# Altium schematic sheet Sensor.SchDoc
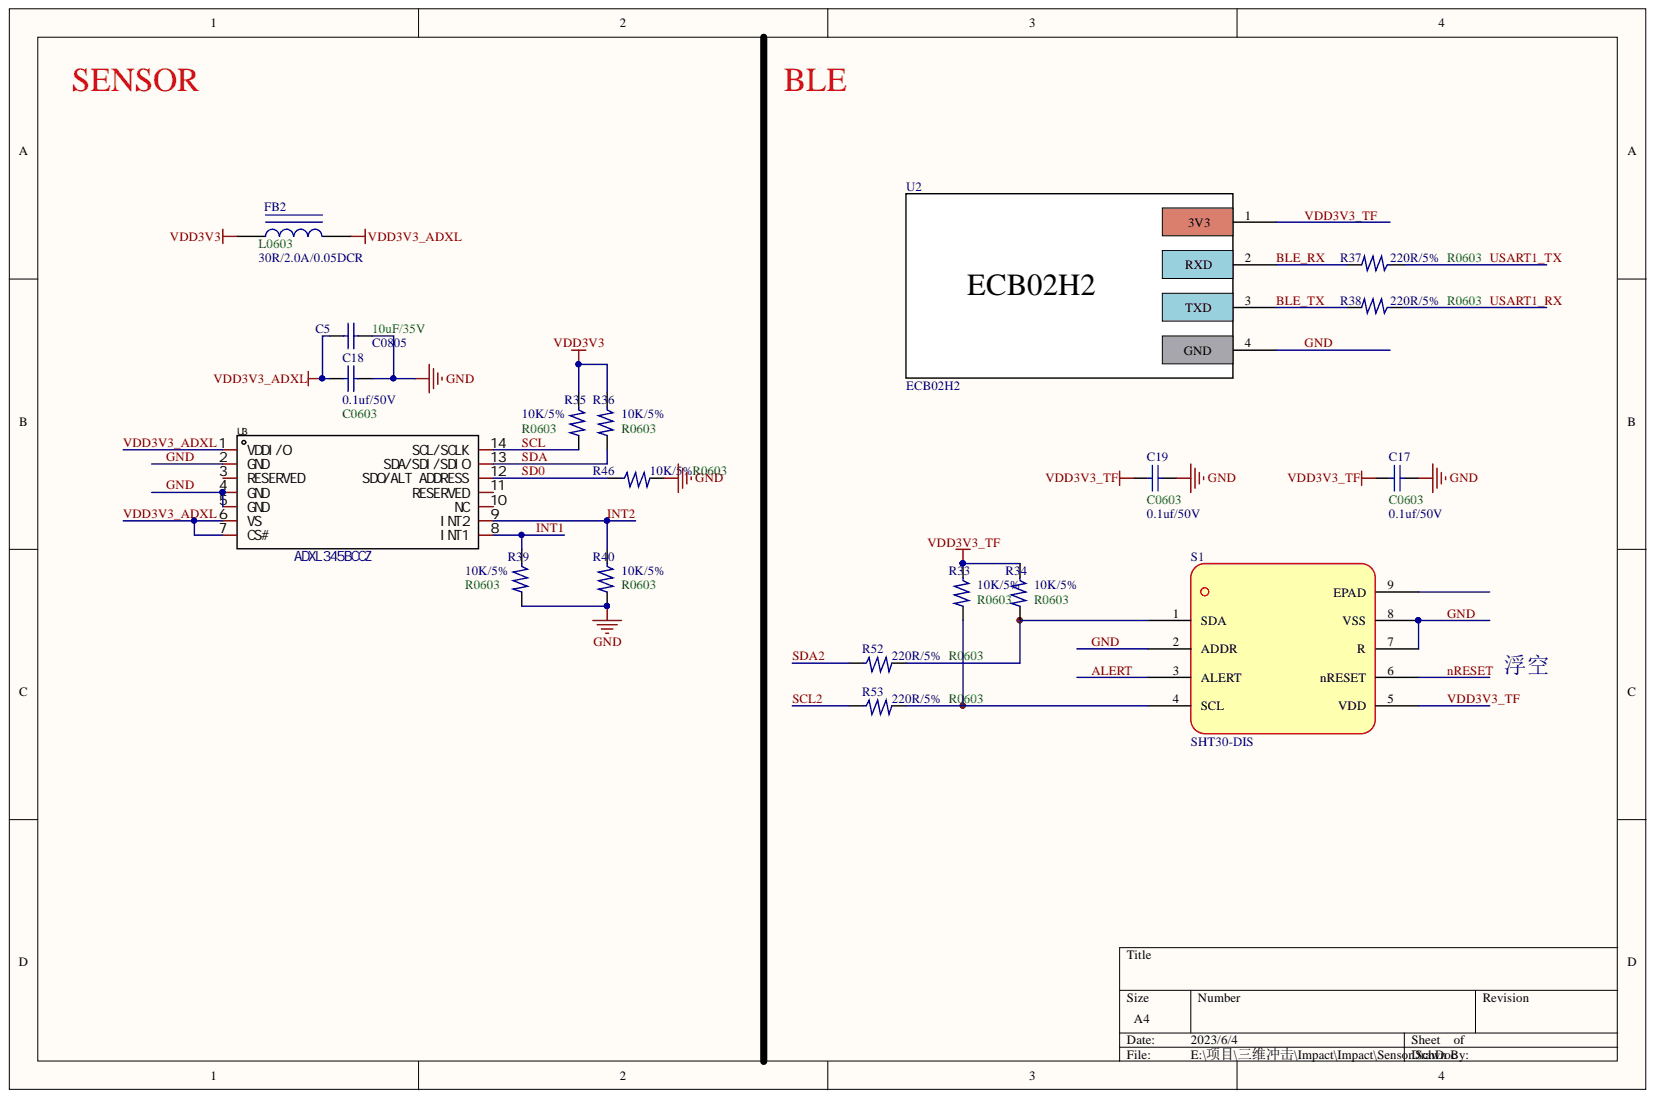
[diagram p0: Sensor.SchDoc]

## Parts
C5  10uF/35V  value C0805  [0805_C]
C17  C0603  value 0.1uf/50V  [0603_C]
C18  0.1uf/50V  [C0603]
C19  C0603  value 0.1uf/50V  [0603_C]
FB2  30R/2.0A/0.05DCR  Package: L0603  [0603_L]
R33  10K/5%  [R0603]
R34  10K/5%  [R0603]
R35  10K/5%  [R0603]
R36  10K/5%  [R0603]
R37  220R/5%  [R0603]
R38  220R/5%  [R0603]
R39  10K/5%  [R0603]
R40  10K/5%  [R0603]
R46  10K/5%  [R0603]
R52  220R/5%  [R0603]
R53  220R/5%  [R0603]
S1  SHT30-DIS  [DFN-8]
U2  ECB02H2  [HDR1X4]
U3  ADXL345BCCZ  [LGA-14_L5.0-W3.0-P0.80-BL]

## Nets
VDD3V3: FB2.1 R35.2 R36.2
ALERT: S1.3(ALERT)
BLE_RX: R37.1 U2.2(RXD)
BLE_TX: R38.1 U2.3(TXD)
GND: C5.2 C17.1 C18.2 C19.1 R39.1 R40.1 R46.1 S1.2(ADDR) S1.7(R) S1.8(VSS) U2.4(GND) U3.2(GND) U3.4(GND) U3.5(GND)
INT1: R39.2 U3.8(INT1)
INT2: R40.2 U3.9(INT2)
NRESET: S1.6(nRESET)
SCL: R35.1 U3.14(SCL/SCLK)
SCL2: R53.2
SD0: R46.2 U3.12(ADDRESS)
SDA: R36.1 U3.13(SDA/SDI/SDIO)
SDA2: R52.2
USART1_RX: R38.2
USART1_TX: R37.2
VDD3V3_ADXL: C5.1 C18.1 FB2.2 U3.1(VDDI/O) U3.6(VS) U3.7(CS#)
VDD3V3_TF: C17.2 C19.2 R33.2 R34.2 S1.5(VDD) U2.1(3V3)
NetR33_1: R33.1 R53.1 S1.4(SCL)
NetR34_1: R34.1 R52.1 S1.1(SDA)

Not connected: S1 9(EPAD); U3 3(RESERVED) 10(NC) 11(RESERVED)

## Library data of the parts
C5 = CAP: Component Kind: Standard; Description: 10uf/35V
C17 C19 = CAP: 0.1uf/50V; Component Kind: Standard
C18 = CAP: Capacitor; Component Kind: Standard
FB2 = 0603 3.9nH 0.3nH: 贴片电感; Component Kind: Standard; ComponentLink1Description: 供应商链接; ComponentLink1URL: http://www.szlcsc.com/product/details_14473.html; Library Name: Miscellaneous Devices LC.IntLib; Supplier: LC; SuppliersPartNumber: C13818
R33-R40 R46 R52 R53 = 电阻: Component Kind: Standard
S1 = SHT30-DIS-B: Component Kind: Standard
U3 = ADXL345BCCZ: Manufacturer: ADI(亚德诺)/LINEAR; Add into BOM: yes; Component Kind: Standard; Convert to PCB: yes; Datasheet: https://atta.szlcsc.com/upload/public/pdf/source/20201030/C579456_55C163C42E67F11674CE9C462EA8849E.pdf; Device: ADXL345BCCZ; JLCPCB Part Class: Extended Part; LCSC Part Name: 加速度计 ADXL345BCCZ; Manufacturer Part: ADXL345BCCZ; Name: ADXL345BCCZ; PCB3D: LGA-14_L3.0-W5.0-H1.0-P0.80; Supplier: LCSC; Supplier Footprint: LGA-14; Supplier Part: C579456; Symbol: ADXL345BCCZ
U2 = ECB02H2: Component Kind: Standard; Library Name: ECB02蓝牙模块原理图库.SchLib
